# Altium schematic sheet mcu.SchDoc
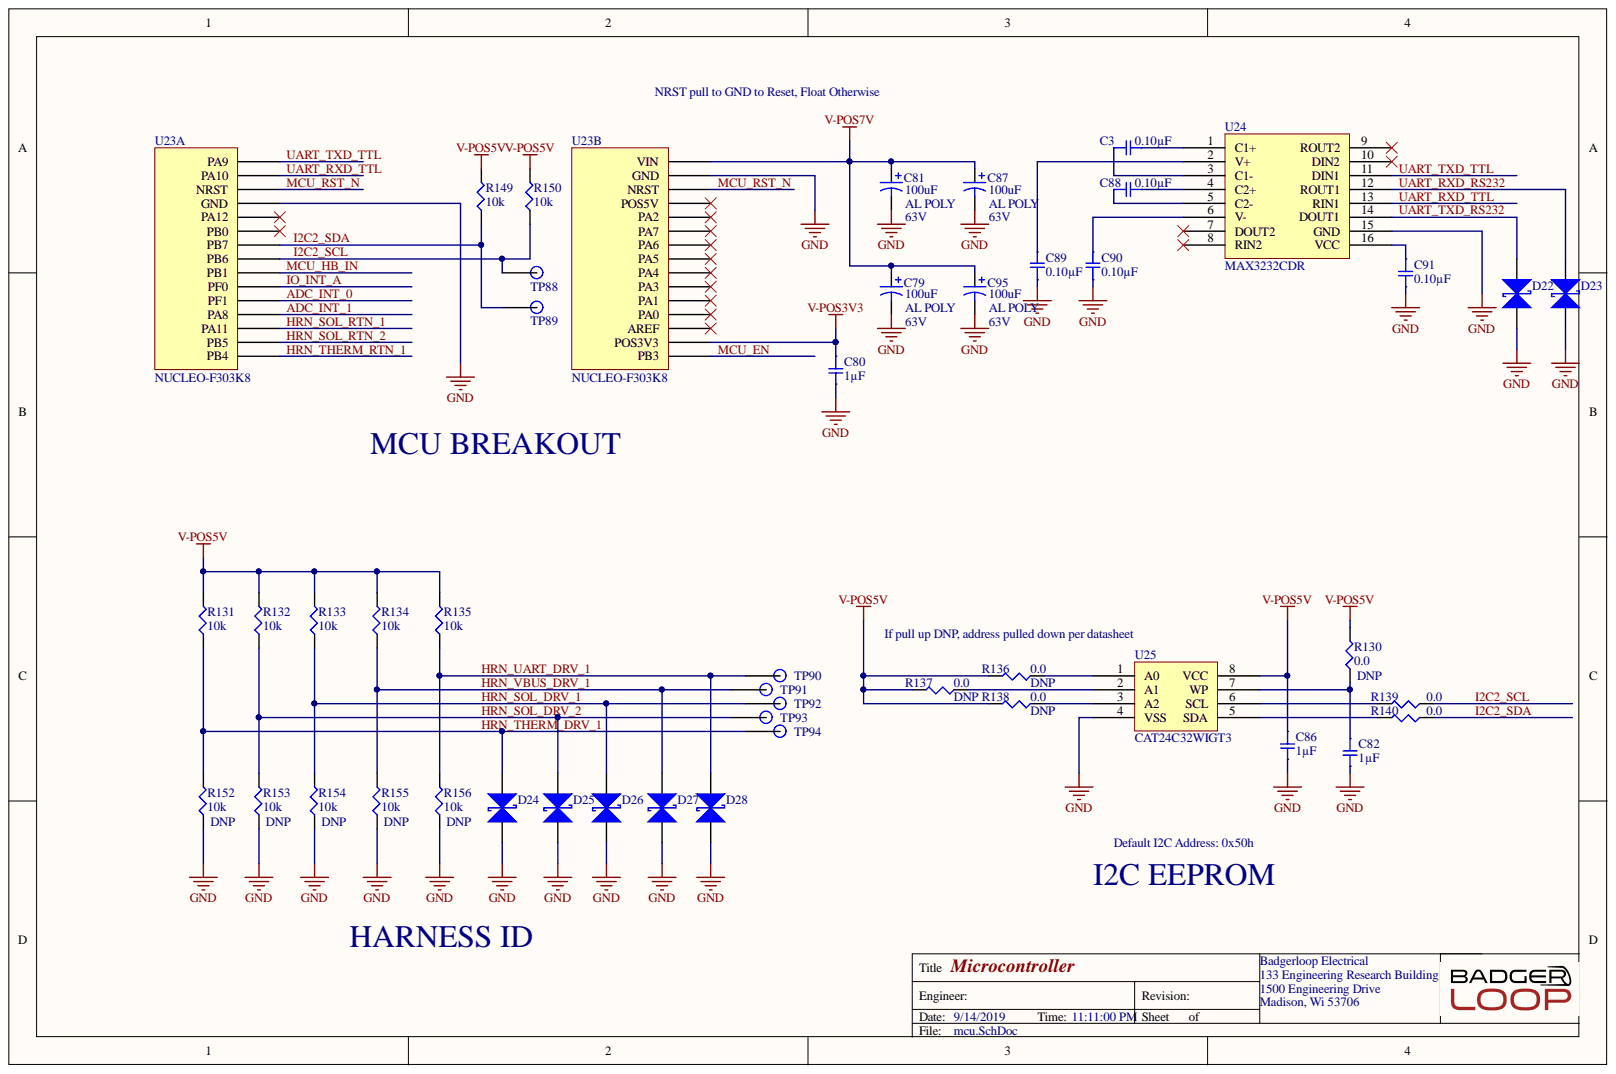
[diagram p0: mcu.SchDoc]

## Parts
C3  0.10µF  [SMD-0805C]
C79  Capacitance: 100uF  Voltage: 63V  [CAPAE110103X126M]  (hidden comment: PCR1J101MCL1GS)
C80  1µF  [SMD-0805C]
C81  Capacitance: 100uF  Voltage: 63V  [CAPAE110103X126M]  (hidden comment: PCR1J101MCL1GS)
C82  1µF  [SMD-0805C]
C86  1µF  [SMD-0805C]
C87  Capacitance: 100uF  Case/Package: AL POLY  Voltage: 63V  [CAPAE110103X126M]  (hidden comment: PCR1J101MCL1GS)
C88  0.10µF  [SMD-0805C]
C89  0.10µF  [SMD-0805C]
C90  0.10µF  [SMD-0805C]
C91  0.10µF  [SMD-0805C]
C95  Capacitance: 100uF  Case/Package: AL POLY  Voltage: 63V  [CAPAE110103X126M]  (hidden comment: PCR1J101MCL1GS)
D22  SMBJ15A  [DIOM4436X26N]  (value not drawn)
D23  SMBJ15A  [DIOM4436X26N]  (value not drawn)
D24  SMBJ15A  [DIOM4436X26N]  (value not drawn)
D25  SMBJ15A  [DIOM4436X26N]  (value not drawn)
D26  SMBJ15A  [DIOM4436X26N]  (value not drawn)
D27  SMBJ15A  [DIOM4436X26N]  (value not drawn)
D28  SMBJ15A  [DIOM4436X26N]  (value not drawn)
R130  0.0  [SMD-0805-RES]
R131  10k  [SMD-0805-RES]
R132  10k  [SMD-0805-RES]
R133  10k  [SMD-0805-RES]
R134  10k  [SMD-0805-RES]
R135  10k  [SMD-0805-RES]
R136  0.0  [SMD-0805-RES]
R137  0.0  [SMD-0805-RES]
R138  0.0  [SMD-0805-RES]
R139  0.0  [SMD-0805-RES]
R140  0.0  [SMD-0805-RES]
R149  10k  [SMD-0805-RES]
R150  10k  [SMD-0805-RES]
R152  10k  [SMD-0805-RES]
R153  10k  [SMD-0805-RES]
R154  10k  [SMD-0805-RES]
R155  10k  [SMD-0805-RES]
R156  10k  [SMD-0805-RES]
TP88  TP  [Test Point]
TP89  TP  [Test Point]
TP90  TP  [Test Point]
TP91  TP  [Test Point]
TP92  TP  [Test Point]
TP93  TP  [Test Point]
TP94  TP  [Test Point]
U23  NUCLEO-F303K8  [NUCLEO-F303K8]  (parts A,B)
U24  MAX3232CDR  [SOP127P1060X175-16N]
U25  CAT24C32WIGT3  [SOP127P600X175-8N]

## Nets
GND: C79.2 C80.2 C81.2 C82.2 C86.2 C87.2 C89.1 C90.1 C91.2 C95.2 D22.1 D23.1 D24.1 D25.1 D26.1 D27.1 D28.1 R152.1 R153.1 R154.1 R155.1 R156.1 U23.3.4(GND) U23.4.2(GND) U24.15(GND) U25.4(VSS)
V-POS3V3: C80.1 U23.4.14(POS3V3)
V-POS5V: C86.1 R130.2 R131.2 R132.2 R133.2 R134.2 R135.2 R136.2 R137.2 R138.2 R149.2 R150.2 U25.8(VCC)
V-POS7V: C79.1 C81.1 C87.1 C95.1 U23.4.1(VIN)
ADC_INT_0: U23.3.11(PF1)
ADC_INT_1: U23.3.12(PA8)
HRN_SOL_DRV_1: D26.2 R133.1 R154.2 TP92.1
HRN_SOL_DRV_2: D25.2 R132.1 R153.2 TP93.1
HRN_SOL_RTN_1: U23.3.13(PA11)
HRN_SOL_RTN_2: U23.3.14(PB5)
HRN_THERM_DRV_1: D24.2 R131.1 R152.2 TP94.1
HRN_THERM_RTN_1: U23.3.15(PB4)
HRN_UART_DRV_1: D28.2 R135.1 R156.2 TP90.1
HRN_VBUS_DRV_1: D27.2 R134.1 R155.2 TP91.1
I2C2_SCL: R139.1 R150.1 TP88.1 U23.3.8(PB6)
I2C2_SDA: R140.1 R149.1 TP89.1 U23.3.7(PB7)
IO_INT_A: U23.3.10(PF0)
MCU_EN: U23.4.15(PB3)
MCU_HB_IN: U23.3.9(PB1)
MCU_RST_N: U23.3.3(NRST) U23.4.3(NRST)
UART_RXD_RS232: D23.2 U24.12(ROUT1)
UART_RXD_TTL: U23.3.2(PA10) U24.13(RIN1)
UART_TXD_RS232: D22.2 U24.14(DOUT1)
UART_TXD_TTL: U23.3.1(PA9) U24.11(DIN1)
NetC3_1: C3.1 U24.3(C1-)
NetC3_2: C3.2 U24.1(C1+)
NetC82_1: C82.1 R130.1 U25.7(WP)
NetC88_1: C88.1 U24.5(C2-)
NetC88_2: C88.2 U24.4(C2+)
NetC89_2: C89.2 U24.2(V+)
NetC90_2: C90.2 U24.6(V-)
NetC91_1: C91.1 U24.16(VCC)
NetR136_1: R136.1 U25.1(A0)
NetR137_1: R137.1 U25.2(A1)
NetR138_1: R138.1 U25.3(A2)
NetR139_2: R139.2 U25.6(SCL)
NetR140_2: R140.2 U25.5(SDA)

Not connected: U23 3.5(PA12) 3.6(PB0) 4.4(POS5V) 4.5(PA2) 4.6(PA7) 4.7(PA6) 4.8(PA5) 4.9(PA4) 4.10(PA3) 4.11(PA1) 4.12(PA0) 4.13(AREF); U24 7(DOUT2) 8(RIN2) 9(ROUT2) 10(DIN2)

## Library data of the parts
C3 C88-C90 = GRM219R71C104JA01D: CAP CER 0.1UF 16V X7R 0805; Manufacturer: Murata Electronics North America; @ qty: 0; Minimum Quantity: 1; Tolerance: ±5%; Unit Price (USD): 0.12; Applications: General Purpose; Component Kind: Standard; Datasheets: -; Digi-Key Part Number: 490-12557-1-ND; Factory Stock: 0; Features: -; Height - Seated (Max): -; Image: //media.digikey.com/Renders/Murata%20Renders/0805%20(2012%20Metric)%20.85mm.jpg; Lead Spacing: -; Lead Style: -; Library Name: capacitors.SchLib; Mounting Type: Surface Mount, MLCC; Operating Temperature: -55°C ~ 125°C; Package / Case: 0805 (2012 Metric); Packaging: Cut Tape (CT); Part Number: GRM219R71C104JA01D; Part Status: Active; Quantity Available: 6635; Ratings: -; Series: GRM; Size / Dimension: 0.079" L x 0.049" W (2.00mm x 1.25mm); Temperature Coefficient: X7R; Thickness (Max): 0.037" (0.95mm); Value: 0.10µF; Voltage: 16V
C79 C81 C87 C95 = PCR1J101MCL1GS: CAP ALUM POLY 100UF 20% 63V SMD; Manufacturer: Nichicon; Dissipation Factor: 0.08%; ESR (Equivalent Series Resistance): 24mR; Leakage Current: 3uA; Life (Hours): 4000Hour; Ripple Current: 3A; Component Kind: Standard; ComponentLink1Description: https://datasheet.ciiva.com/29551/e-ch-ta-29551389.pdf?src-supplier=Digi-Key; ComponentLink1URL: https://datasheet.ciiva.com/29551/e-ch-ta-29551389.pdf?src-supplier=Digi-Key; ComponentLink2Description: https://datasheet.ciiva.com/29551/e-ch-ta-29551390.pdf?src-supplier=Digi-Key; ComponentLink2URL: https://datasheet.ciiva.com/29551/e-ch-ta-29551390.pdf?src-supplier=Digi-Key; ComponentLink3Description: https://datasheet.ciiva.com/29551/e-ch-ta-29551391.pdf?src-supplier=Digi-Key; ComponentLink3URL: https://datasheet.ciiva.com/29551/e-ch-ta-29551391.pdf?src-supplier=Digi-Key; Depth: 10.3mm; Diameter: 10mm; Height: 12.7mm; Height - Seated (Max): 0.504inch; Lead Free: Lead Free; Lead Pitch: 4.6mm; Length: 12.7mm; Library Name: capacitors.SchLib; Manufacturer Part Number: PCR1J101MCL1GS; Max Operating Temperature: 125°C; Min Operating Temperature: -55°C; Mount: Surface Mount,PCB; Package Quantity: 1; Packaging: Tape and Reel; Pricing 1: 1=3.63000, 10=2.92800, 100=2.28380 (USD); RoHS: Yes; Stock 1: 11476; Supplier 1: Digi-Key; Supplier Part Number 1: 493-13783-1-ND; Termination: SMD/SMT; Tolerance: 20%; Voltage Rating: 63V; Voltage Rating (DC): 63V; Weight: 0.045856oz
  C79 C81: Case/Package: AL POLY
C80 C82 C86 = GRM21BR71H105KA12L: CAP CER 1UF 50V X7R 0805; Manufacturer: Murata Electronics North America; @ qty: 0; Minimum Quantity: 1; Tolerance: ±10%; Unit Price (USD): 0.25; Applications: General Purpose; Component Kind: Standard; Datasheets: http://www.murata.com/~/media/webrenewal/support/library/catalog/products/capacitor/mlcc/c02e.pdf; Digi-Key Part Number: 490-4736-1-ND; Factory Stock: 0; Features: -; Height - Seated (Max): -; Image: //media.digikey.com/Renders/Murata%20Renders/0805%20(2012%20Metric)%20.85mm.jpg; Lead Spacing: -; Lead Style: -; Library Name: capacitors.SchLib; Mounting Type: Surface Mount, MLCC; Operating Temperature: -55°C ~ 125°C; Package / Case: 0805 (2012 Metric); Packaging: Cut Tape (CT); Part Number: GRM21BR71H105KA12L; Part Status: Active; Quantity Available: 988032; Ratings: -; Series: GRM; Size / Dimension: 0.079" L x 0.049" W (2.00mm x 1.25mm); Temperature Coefficient: X7R; Thickness (Max): 0.053" (1.35mm); Value: 1µF; Voltage: 50V
C91 = GRM219R71E104KA01D: CAP CER 0.1UF 25V X7R 0805; Manufacturer: Murata Electronics North America; @ qty: 0; Minimum Quantity: 1; Tolerance: ±10%; Unit Price (USD): 0.12; Applications: General Purpose; Component Kind: Standard; Datasheets: http://www.murata.com/~/media/webrenewal/support/library/catalog/products/capacitor/mlcc/c02e.pdf; Digi-Key Part Number: 490-12754-1-ND; Factory Stock: 0; Features: -; Height - Seated (Max): -; Image: //media.digikey.com/Renders/Murata%20Renders/0805%20(2012%20Metric)%20.85mm.jpg; Lead Spacing: -; Lead Style: -; Library Name: capacitors.SchLib; Mounting Type: Surface Mount, MLCC; Operating Temperature: -55°C ~ 125°C; Package / Case: 0805 (2012 Metric); Packaging: Cut Tape (CT); Part Number: GRM219R71E104KA01D; Part Status: Active; Quantity Available: 8594; Ratings: -; Series: GRM; Size / Dimension: 0.079" L x 0.049" W (2.00mm x 1.25mm); Temperature Coefficient: X7R; Thickness (Max): 0.037" (0.95mm); Value: 0.10µF; Voltage: 25V
D22-D28 = SMBJ15A: TVS DIODE 15V 24.4V DO214AA; Manufacturer: Littelfuse; Breakdown Voltage: 16.7V; Direction: Unidirectional; Element Configuration: Single; Leakage Current: 1uA; Max Breakdown Voltage: 18.5V; Max Power Dissipation: 5W; Max Surge Current: 24.6A; Number of Channels: 1; Peak Pulse Power: 600W; Power Line Protection: No; Test Current: 1mA; Case/Package: SMB; Clamping Voltage: 24.4V; Component Kind: Standard; ComponentLink1Description: https://datasheet.ciiva.com/34301/1078555-34301957.pdf?src-supplier=Jameco; ComponentLink1URL: https://datasheet.ciiva.com/34301/1078555-34301957.pdf?src-supplier=Jameco; ComponentLink2Description: https://datasheet.ciiva.com/25624/0900766b810f4eeb-25624826.pdf?src-supplier=RSComponents; ComponentLink2URL: https://datasheet.ciiva.com/25624/0900766b810f4eeb-25624826.pdf?src-supplier=RSComponents; ComponentLink3Description: https://datasheet.ciiva.com/46385/13057-46385079.pdf?src-supplier=Element14; ComponentLink3URL: https://datasheet.ciiva.com/46385/13057-46385079.pdf?src-supplier=Element14; ComponentLink4Description: https://datasheet.ciiva.com/331/littelfuse-tvs-diode-smbj-datasheet-pdf-331176.pdf?src-supplier=Digi-Key; ComponentLink4URL: https://datasheet.ciiva.com/331/littelfuse-tvs-diode-smbj-datasheet-pdf-331176.pdf?src-supplier=Digi-Key; ComponentLink5Description: https://datasheet.ciiva.com/331/littelfuse-tvs-diode-smbj-datasheet-pdf-331177.pdf?src-supplier=Digi-Key; ComponentLink5URL: https://datasheet.ciiva.com/331/littelfuse-tvs-diode-smbj-datasheet-pdf-331177.pdf?src-supplier=Digi-Key; ComponentLink6Description: https://datasheet.ciiva.com/331/littelfuse-tvs-diode-smbj-datasheet-pdf-331178.pdf?src-supplier=Digi-Key; ComponentLink6URL: https://datasheet.ciiva.com/331/littelfuse-tvs-diode-smbj-datasheet-pdf-331178.pdf?src-supplier=Digi-Key; Composition: Zener; Height: 2.237mm; Lead Free: Lead Free; Length: 4.57mm; Library Name: misc.SchLib; Manufacturer Part Number: SMBJ15A; Max Operating Temperature: 150°C; Max Reverse Leakage Current: 1uA; Min Breakdown Voltage: 16.7V; Min Operating Temperature: -65°C; Mount: Surface Mount; Number of Elements: 1; Number of Pins: 2; Number of Unidirectional Channels: 1; Operating Supply Voltage: 15V; Package Quantity: 3000; Packaging: Tape and Reel; Peak Pulse Current: 24.6A; Polarity: Unidirectional; Power Dissipation: 600W; Pricing 1: 1=0.45000, 10=0.33900, 25=0.30520, 100=0.21090, 250=0.17760, 500=0.14430, 1000=0.11100 (USD); Radiation Hardening: No; REACH SVHC: Unknown; Reverse Breakdown Voltage: 16.7V; Reverse Standoff Voltage: 15V; RoHS: Yes; Stock 1: 73945; Supplier 1: Digi-Key; Supplier Part Number 1: SMBJ15ALFCT-ND; Termination: SMD/SMT; Voltage Rating (DC): 15V; Width: 3.94mm
R130 R136-R140 = ERJ-6GEY0R00V: RES SMD 0.0 OHM JUMPER 1/8W 0805; Manufacturer: Panasonic Electronic Components; @ qty: 1500; Factory Stock: 0; Minimum Quantity: 1; Power (Watts): 0.125W, 1/8W; Unit Price (USD): 0.00544; Component Kind: Standard; Composition: Thick Film; Datasheets: http://industrial.panasonic.com/www-cgi/jvcr13pz.cgi?E+PZ+3+AOA0001+ERJ6GEY0R00V+7+WW; Digi-Key Part Number: P0.0ACT-ND; Failure Rate: -; Features: Automotive AEC-Q200; Height - Seated (Max): 0.028" (0.70mm); Image: //media.digikey.com/Renders/Panasonic%20Renders/ERJ-0805%20Pkg.jpg; Library Name: resistors.SchLib; Number of Terminations: 2; Operating Temperature: -55°C ~ 155°C; Package / Case: 0805 (2012 Metric); Packaging: Cut Tape (CT); Part Number: ERJ-6GEY0R00V; Part Status: Active; Quantity Available: 1597627; Series: ERJ; Size / Dimension: 0.079" L x 0.049" W (2.00mm x 1.25mm); Supplier Device Package: 0805; Temperature Coefficient: -; Tolerance: Jumper; Value: 0.0
  R130 R136-R138: DNP: DNP
R131-R135 R149 R150 R152-R156 = ERJ-P06J103V: RES SMD 10K OHM 5% 1/2W 0805; Manufacturer: Panasonic Electronic Components; @ qty: 1500; Factory Stock: 0; Minimum Quantity: 1; Power (Watts): 0.5W, 1/2W; Temperature Coefficient: ±200ppm/°C; Unit Price (USD): 0.01839; Component Kind: Standard; Composition: Thick Film; Datasheets: https://industrial.panasonic.com/cdbs/www-data/pdf/RDA0000/AOA0000C243.pdf; Digi-Key Part Number: P10KADCT-ND; Failure Rate: -; Features: Automotive AEC-Q200, Pulse Withstanding; Height - Seated (Max): 0.028" (0.70mm); Image: //media.digikey.com/Renders/Panasonic%20Renders/ERJ-0805%20Pkg.jpg; Library Name: resistors.SchLib; Number of Terminations: 2; Operating Temperature: -55°C ~ 155°C; Package / Case: 0805 (2012 Metric); Packaging: Cut Tape (CT); Part Number: ERJ-P06J103V; Part Status: Active; Quantity Available: 413576; Series: ERJ; Size / Dimension: 0.079" L x 0.049" W (2.00mm x 1.25mm); Supplier Device Package: 0805; Tolerance: ±5%; Value: 10k
  R152-R156: DNP: DNP
TP88-TP94 = TP: SMD TEST POINT; Component Kind: Standard; Library Name: misc.SchLib
U23 = NUCLEO-F303K8: BOARD NUCLEO STM32F303K8T6; Manufacturer: STMicroelectronics; Core Architecture: ARM; Evaluation Kit: Yes; Number of Bits: 32; Component Kind: Standard; ComponentLink1Description: http://www.farnell.com/datasheets/2064195.pdf?src-supplier=Farnell; ComponentLink1URL: http://www.farnell.com/datasheets/2064195.pdf?src-supplier=Farnell; ComponentLink2Description: http://www.st.com/content/ccc/resource/technical/document/data_brief/b1/d8/13/d4/b0/b7/4b/6e/DM00214578.pdf/files/DM00214578.pdf/jcr:content/translations/en.DM00214578.pdf?src-supplier=Digi-Key; ComponentLink2URL: http://www.st.com/content/ccc/resource/technical/document/data_brief/b1/d8/13/d4/b0/b7/4b/6e/DM00214578.pdf/files/DM00214578.pdf/jcr:content/translations/en.DM00214578.pdf?src-supplier=Digi-Key; ComponentLink3Description: http://www.farnell.com/datasheets/1966773.pdf?src-supplier=Element14; ComponentLink3URL: http://www.farnell.com/datasheets/1966773.pdf?src-supplier=Element14; ComponentLink4Description: http://www.farnell.com/datasheets/2064195.pdf?src-supplier=Newark; ComponentLink4URL: http://www.farnell.com/datasheets/2064195.pdf?src-supplier=Newark; ComponentLink5Description: http://www.st.com/st-web-ui/static/active/en/resource/technical/document/user_manual/DM00116575.pdf?s_searchtype=keyword&src-supplier=Avnet+Asia; ComponentLink5URL: http://www.st.com/st-web-ui/static/active/en/resource/technical/document/user_manual/DM00116575.pdf?s_searchtype=keyword&src-supplier=Avnet+Asia; ComponentLink6Description: http://www.st.com/st-web-ui/static/active/en/resource/technical/document/user_manual/DM00116575.pdf?s_searchtype=keyword&src-supplier=Avnet; ComponentLink6URL: http://www.st.com/st-web-ui/static/active/en/resource/technical/document/user_manual/DM00116575.pdf?s_searchtype=keyword&src-supplier=Avnet; Lead Free: Lead Free; Library Name: ic.SchLib; Manufacturer Part Number: NUCLEO-F303K8; Pricing 1: 1=10.99000 (USD); REACH SVHC: No SVHC; RoHS: Yes; Stock 1: 171; Supplier 1: Digi-Key; Supplier Part Number 1: 497-15981-ND
U24 = MAX3232CDR: IC DRVR/RCVR MULTCH RS232 16SOIC; Manufacturer: Texas Instruments; Data Rate: 250Kbps; ESD Protection: Yes; Logic Function: Transceiver,Receiver; Max Supply Current: 1mA; Max Supply Voltage: 5.5V; Min Supply Voltage: 3V; Number of Drivers: 2; Number of Transceivers: 1; Operating Supply Voltage: 5V; Output Voltage: 5.4V; Simplex/Duplex: Full Duplex; Case/Package: D; Component Kind: Standard; ComponentLink1Description: https://datasheet.ciiva.com/41461/001186897-da-01-en-ic-drvr-rcvr-mult-max3232cdr-soic-16-tid-41461424.pdf?src-supplier=Conrad; ComponentLink1URL: https://datasheet.ciiva.com/41461/001186897-da-01-en-ic-drvr-rcvr-mult-max3232cdr-soic-16-tid-41461424.pdf?src-supplier=Conrad; ComponentLink2Description: https://datasheet.ciiva.com/1702/max3232-1702778.pdf?src-supplier=Digi-Key; ComponentLink2URL: https://datasheet.ciiva.com/1702/max3232-1702778.pdf?src-supplier=Digi-Key; ComponentLink3Description: https://datasheet.ciiva.com/1702/max3232-1702779.pdf?src-supplier=Digi-Key; ComponentLink3URL: https://datasheet.ciiva.com/1702/max3232-1702779.pdf?src-supplier=Digi-Key; ComponentLink4Description: https://datasheet.ciiva.com/1702/max3232-1702780.pdf?src-supplier=Digi-Key; ComponentLink4URL: https://datasheet.ciiva.com/1702/max3232-1702780.pdf?src-supplier=Digi-Key; ComponentLink5Description: https://datasheet.ciiva.com/46397/1882754-46397998.pdf?src-supplier=Element14; ComponentLink5URL: https://datasheet.ciiva.com/46397/1882754-46397998.pdf?src-supplier=Element14; Contact Plating: Gold; Height: 1.58mm; Lead Free: Lead Free; Length: 9.9mm; Library Name: ic.SchLib; Manufacturer Part Number: MAX3232CDR; Max Operating Temperature: 85°C; Min Operating Temperature: -40°C; Mount: Surface Mount; Nominal Supply Current: 1mA; Number of Pins: 16; Number of Receivers: 2; Number of Transmitters: 2; Operating Supply Current: 1mA; Package Quantity: 1; Packaging: Tape and Reel; Pricing 1: 1=1.89000, 10=1.69500, 100=1.36240, 500=1.11936, 1000=0.92746 (USD); Radiation Hardening: No; REACH SVHC: No SVHC; RoHS: Yes; Stock 1: 18808; Supplier 1: Digi-Key; Supplier Part Number 1: 296-13094-1-ND; Weight: 0.004998oz; Width: 3.91mm
U25 = CAT24C32WIGT3: IC EEPROM 32K I2C 1MHZ 8SOIC; Manufacturer: ON Semiconductor; Access Time: 3500ns; Density: 32Kb; Frequency: 400kHz; Interface: I2C,Serial,2-Wire; Max Frequency: 100kHz; Max Supply Voltage: 5.5V; Min Supply Voltage: 1.7V; Nominal Supply Current: 2mA; Number of Words: 4096; Operating Supply Voltage: 5V; Case/Package: SOIC; Component Kind: Standard; ComponentLink1Description: https://datasheet.ciiva.com/15123/getdatasheetpartid-144155-15123242.pdf?src-supplier=Verical; ComponentLink1URL: https://datasheet.ciiva.com/15123/getdatasheetpartid-144155-15123242.pdf?src-supplier=Verical; ComponentLink2Description: https://datasheet.ciiva.com/26870/cat24c32wi-gt3-26870507.pdf?src-supplier=IIIC; ComponentLink2URL: https://datasheet.ciiva.com/26870/cat24c32wi-gt3-26870507.pdf?src-supplier=IIIC; ComponentLink3Description: https://datasheet.ciiva.com/1904/cat24c32-d-1904849.pdf?src-supplier=Digi-Key; ComponentLink3URL: https://datasheet.ciiva.com/1904/cat24c32-d-1904849.pdf?src-supplier=Digi-Key; ComponentLink4Description: https://datasheet.ciiva.com/1904/cat24c32-d-1904850.pdf?src-supplier=Digi-Key; ComponentLink4URL: https://datasheet.ciiva.com/1904/cat24c32-d-1904850.pdf?src-supplier=Digi-Key; ComponentLink5Description: https://datasheet.ciiva.com/1904/cat24c32-d-1904851.pdf?src-supplier=Digi-Key; ComponentLink5URL: https://datasheet.ciiva.com/1904/cat24c32-d-1904851.pdf?src-supplier=Digi-Key; ComponentLink6Description: https://datasheet.ciiva.com/16393/cat24c32-d-16393221.pdf?src-supplier=Future+Electronics; ComponentLink6URL: https://datasheet.ciiva.com/16393/cat24c32-d-16393221.pdf?src-supplier=Future+Electronics; ComponentLink7Description: https://datasheet.ciiva.com/16471/cat24c32-d-16471335.pdf?src-supplier=Avnet+Asia; ComponentLink7URL: https://datasheet.ciiva.com/16471/cat24c32-d-16471335.pdf?src-supplier=Avnet+Asia; ComponentLink8Description: https://datasheet.ciiva.com/3185/cat24c32-d-3185118.pdf?src-supplier=Avnet; ComponentLink8URL: https://datasheet.ciiva.com/3185/cat24c32-d-3185118.pdf?src-supplier=Avnet; ComponentLink9Description: https://datasheet.ciiva.com/22618/1696760-22618478.pdf?src-supplier=Element14; ComponentLink9URL: https://datasheet.ciiva.com/22618/1696760-22618478.pdf?src-supplier=Element14; ComponentLink10Description: https://datasheet.ciiva.com/26216/cat24c32-d-26216670.pdf?src-supplier=Avnet+Europe; ComponentLink10URL: https://datasheet.ciiva.com/26216/cat24c32-d-26216670.pdf?src-supplier=Avnet+Europe; Contact Plating: Gold; Halogen Free: Halogen Free; Height: 1.5mm; Lead Free: Lead Free; Length: 5mm; Library Name: ic.SchLib; Manufacturer Part Number: CAT24C32WIGT3; Max Operating Temperature: 85°C; Memory Size: 32Kb; Memory Type: EEPROM; Min Operating Temperature: -40°C; Mount: Surface Mount; Number of Pins: 8; Package Quantity: 1; Packaging: Tape and Reel; Radiation Hardening: No; REACH SVHC: No SVHC; RoHS: Yes; Stock 1: 0; Supplier 1: Newark; Supplier Part Number 1: 06R0561; Width: 4mm
